# Altium schematic sheet Adafruit_Feather_adapter_Rev._1.21.SchDoc
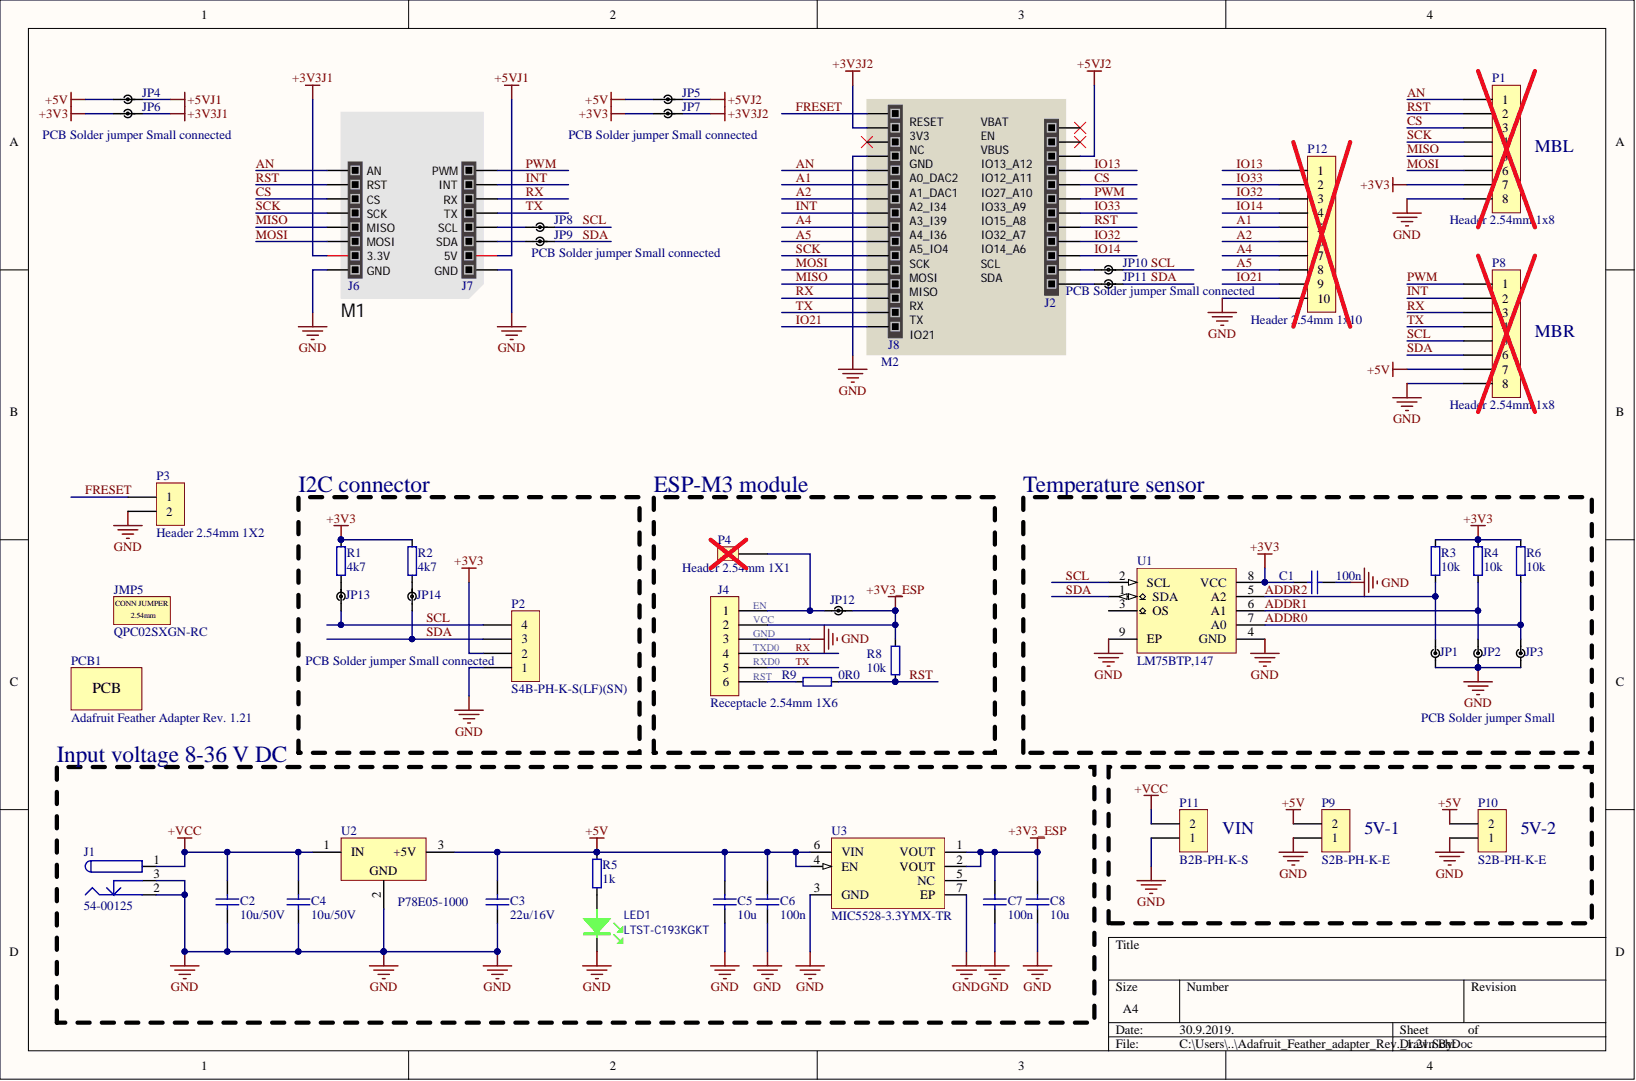
[diagram p0: Adafruit_Feather_adapter_Rev._1.21.SchDoc]

## Parts
C1  100n  [C-0603]
C2  10u/50V  [C-1210]
C3  22u/16V  [C-1210]
C4  10u/50V  [C-1210]
C5  10u  [C-1206]
C6  100n  [C-0603]
C7  100n  [C-0603]
C8  10u  [C-1206]
J1  54-00125  [Conn_54-00125]
J2  CES-112-01-T-S  [SCOKET12X01]  (value not drawn)
J4  Receptacle 2.54mm 1X6  [Receptacle 2.54mm 1X6]
J6  SSQ-108-01-T-S  [MIKROE_ROW_1x8]  (value not drawn)
J7  SSQ-108-01-T-S  [MIKROE_ROW_1x8]  (value not drawn)
J8  SLW-116-01-T-S  [SCOKET16X01]  (value not drawn)
JMP5  QPC02SXGN-RC  (mechanical)
JP1  PCB Solder jumper Small  [PCB solder jumper small]  (no BOM)
JP2  PCB Solder jumper Small  [PCB solder jumper small]  (no BOM)
JP3  PCB Solder jumper Small  [PCB solder jumper small]  (no BOM)
JP4  PCB Solder jumper Small connected  [PCB solder jumper small - connected]
JP5  PCB Solder jumper Small connected  [PCB solder jumper small - connected]
JP6  PCB Solder jumper Small connected  [PCB solder jumper small - connected]
JP7  PCB Solder jumper Small connected  [PCB solder jumper small - connected]
JP8  PCB Solder jumper Small connected  [PCB solder jumper small - connected]
JP9  PCB Solder jumper Small connected  [PCB solder jumper small - connected]
JP10  PCB Solder jumper Small connected  [PCB solder jumper small - connected]
JP11  PCB Solder jumper Small connected  [PCB solder jumper small - connected]
JP12  PCB Solder jumper Small connected  [PCB solder jumper small - connected]
JP13  PCB Solder jumper Small connected  [PCB solder jumper small - connected]
JP14  PCB Solder jumper Small connected  [PCB solder jumper small - connected]
LED1  LTST-C193KGKT  [LED-0603]
M1  MIKROBUS HOST  [MIKROBUS HOST CONN.]  (value not drawn)  (no BOM)
M2  FEATHER  [FEATHERHOST]  (value not drawn)  (no BOM)
P1  Header 2.54mm 1x8  [CONN_Header_2.54mm_1X8]
P2  S4B-PH-K-S(LF)(SN)  [Conn_S4B-PH-K-S]
P3  Header 2.54mm 1X2  [CONN_Header_2.54mm_1X2]
P4  Header 2.54mm 1X1  [Header 2.54mm 1X1]
P8  Header 2.54mm 1x8  [CONN_Header_2.54mm_1X8]
P9  S2B-PH-K-E  [Conn_S2B-PH-K-E]
P10  S2B-PH-K-E  [Conn_S2B-PH-K-E]
P11  B2B-PH-K-S  [Conn B2B-PH-K-S]
P12  Header 2.54mm 1x10  [CONN_Header_2.54mm_1X10]
PCB1  PCB  (mechanical)
R1  4k7  [R-0603]
R2  4k7  [R-0603]
R3  10k  [R-0603]
R4  10k  [R-0603]
R5  1k  [R-0603]
R6  10k  [R-0603]
R8  10k  [R-0603]
R9  0R0  [R-0603]
U1  LM75BTP,147  [DFN50P200X300X75-8T150]
U2  P78E05-1000  [SIP-3]
U3  MIC5528-3.3YMX-TR  [DFN40P120X120X40-6T30X94]

## Nets
+3V3: C1.1 JP6.1 JP7.1 P1.7 P2.2 R1.2 R2.2 R3.2 R4.2 R6.2 U1.8(VCC)
+3V3_ESP: C7.2 C8.2 J4.2 JP12.2 R8.2 U3.1(VOUT) U3.2(VOUT)
+3V3J1: J6.7 JP6.2
+3V3J2: J8.2 JP7.2
+5V: C3.2 C5.2 C6.2 JP4.1 JP5.1 P8.7 P9.2 P10.2 R5.2 U2.3 U3.4(EN) U3.6(VIN)
+5VJ1: J7.7 JP4.2
+5VJ2: J2.3 JP5.2
+VCC: C2.2 C4.2 J1.1 P11.2 U2.1
GND: C1.2 C2.1 C3.1 C4.1 C5.1 C6.1 C7.1 C8.1 J1.2 J1.3 J4.3 J6.8 J7.8 J8.4 JP1.2 JP2.2 JP3.2 LED1.2 P1.8 P2.1 P3.2 P8.8 P9.1 P10.1 P11.1 P12.10 U1.4(GND) U1.9(EP) U2.2 U3.3(GND) U3.7(EP)
A1: J8.6 P12.5
A2: J8.7 P12.6
A4: J8.9 P12.7
A5: J8.10 P12.8
ADDR0: JP3.1 R6.1 U1.7(A0)
ADDR1: JP2.1 R4.1 U1.6(A1)
ADDR2: JP1.1 R3.1 U1.5(A2)
AN: J6.1 J8.5 P1.1
CS: J2.5 J6.3 P1.3
FRESET: J8.1 P3.1
INT: J7.2 J8.8 P8.2
IO13: J2.4 P12.1
IO14: J2.10 P12.4
IO21: J8.16 P12.9
IO32: J2.9 P12.3
IO33: J2.7 P12.2
MISO: J6.5 J8.13 P1.5
MOSI: J6.6 J8.12 P1.6
PWM: J2.6 J7.1 P8.1
RST: J2.8 J6.2 P1.2 R8.1 R9.1
RX: J4.4 J7.3 J8.14 P8.3
SCK: J6.4 J8.11 P1.4
SCL: JP8.2 JP10.2 JP13.1 P2.4 P8.5 U1.2(SCL)
SDA: JP9.2 JP11.2 JP14.1 P2.3 P8.6 U1.1(SDA)
TX: J4.5 J7.4 J8.15 P8.4
NetJ2_11: J2.11 JP10.1
NetJ2_12: J2.12 JP11.1
NetJ4_1: J4.1 JP12.1 P4.1
NetJ4_6: J4.6 R9.2
NetJ7_5: J7.5 JP8.1
NetJ7_6: J7.6 JP9.1
NetJP13_2: JP13.2 R1.1
NetJP14_2: JP14.2 R2.1
NetLED1_1: LED1.1 R5.1

Not connected: J2 1 2; J8 3; U1 3(OS); U3 5(NC)

## Library data of the parts
C1 C6 C7 = C0603-100n-50V-X7R: Capacitor ceramic 100n X7R 50V 0603; BL Part Number: 2000-637; Checked_By: Puskar; Drawn_By: Dodig; Component Kind: Standard; DateModified: 14-06-2013; Library Name: BL_Passive.SchLib; Manufacturer: N/A; Manufacturer Part Number: N/A; Signal Integrity: C0603; Simulation: CAP; Supplier 1: Digi-Key; Supplier Part Number 1: 490-4779-1-ND
C2 C4 = C1210-10u-50V-X7R: Capacitor ceramic 10u ±10% X7R 50V 1210; Manufacturer: Samsung Electro-Mechanics; Manufacturer Part Number: CL32B106KBJNNWE; Drawn_By: Zvonc; Component Kind: Standard; DateModified: 13-05-2019; Supplier 1: Digi-Key; Supplier Part Number 1: 1276-3388-1-ND; Library Name: Tobias_Passive.SchLib
C3 = C1210-22u-16V-X7R: Capacitor ceramic 22u ±15% X7R 16V 1210; BL Part Number: 2001-340; Manufacturer: Murata; Manufacturer Part Number: GCM32ER71C226ME19L; Checked_By: Dodig; Drawn_By: Puskar; Note: Promijenjen DigiKey PN na dobavljivu komponentu; Component Kind: Standard; DateModified: 16-11-2013; Library Name: BL_Passive.SchLib; Simulation: CAP; Supplier 1: Digi-Key; Supplier Part Number 1: 445-3955-1-ND
C5 C8 = C1206-10u-16V-X7R: Capacitor ceramic 10u ±10 X7R 16V 1206; BL Part Number: 2000-447; Checked_By: Zvonc; Drawn_By: Dodig; Component Kind: Standard; DateModified: 04-07-2013; Library Name: BL_Passive.SchLib; Manufacturer: N/A; Manufacturer Part Number: N/A; Simulation: CAP; Supplier 1: Digi-Key; Supplier Part Number 1: 1276-1137-1-ND
J1 = Conn Barrel 54-00125: Barrel Power Connector, 1.1/3.5mm (ID/OD), 48V/3.5A; Manufacturer: Tensility International Corp; Drawn_By: Zvonc; Component Kind: Standard; DateModified: 31-05-2019; Manufacturer Part Number: 54-00125; Supplier 1: Digi-Key; Supplier Part Number 1: 839-1508-ND; Library Name: Tobias_Mechanical.SchLib
J2 = ROW_01X12: Manufacturer: Samtec; Component Kind: Standard; Description: CES-112-01-T-S; Library Name: Connectors.IntLib; Manufacturer Part Number: CES-112-01-T-S; PartNumber: CES-112-01-T-S; Supplier 1: Mouser; Supplier Link 1: https://www.mouser.at/ProductDetail/Samtec/CES-112-01-T-S?qs=sGAEpiMZZMs%252bGHln7q6pm21GiQusZaT7HElltZv7SA0%3d; Supplier Part Number 1: 200-CES11201TS
J4 = Conn Receptacle 2.54mm 1X6: 2.54mm pitch 6 pin (1x6) top entry wire to board connector THT; BL Part Number: 2003-501; Manufacturer: Samtec; Manufacturer Part Number: SSQ-106-01-T-S; Drawn_By: Zvonc; Component Kind: Standard; DateModified: 15-09-2017; Supplier 1: Digi-Key; Supplier Part Number 1: SAM1184-06-ND; Library Name: Tobias_Mechanical.SchLib
J6 J7 = MIKROE_ROW_01X08: Manufacturer: Samtec; Component Kind: Standard; Description: SSQ-108-01-T-S; Library Name: Connectors.SchLib; Manufacturer Part Number: SSQ-108-01-T-S; PartNumber: SSQ-108-01-T-S; Supplier 1: Mouser; Supplier Link 1: https://www.mouser.at/ProductDetail/Samtec/SSQ-108-01-T-S?qs=sGAEpiMZZMs%252bGHln7q6pm8Vn94ktop%2fJQMA9o%252bSmScjSBLV7TdKl6g==; Supplier Part Number 1: 200-SSQ10801TS
J8 = ROW_01X16: Manufacturer: Samtec; Component Kind: Standard; Description: SLW-116-01-T-S; Library Name: Connectors.IntLib; Manufacturer Part Number: SLW-116-01-T-S; PartNumber: SLW-116-01-T-S; Supplier 1: Mouser; Supplier Link 1: https://www.mouser.at/ProductDetail/Samtec/SLW-116-01-T-S?qs=sGAEpiMZZMs%252bGHln7q6pm1g2HIpDMMemmyoSwZxINYmZdVcAL1EU4A%3d%3d; Supplier Part Number 1: 200-SLW11601TS
JMP5 = Conn Jumper 2.54mm: CONN JUMPER SHORTING .100" GOLD; BL Part Number: 2000-174; Manufacturer: Sullins Connector Solutions; Checked_By: Zvonc; Drawn_By: Dodig; DateModified: 15-06-2016; Library Name: BL_Mechanical.SchLib; Manufacturer Part Number: QPC02SXGN-RC; Supplier 1: Digi-Key; Supplier Part Number 1: S9337-ND
JP1-JP3 = PCB Solder jumper Small: Checked_By: Dodig; Drawn_By: Puskar; BL Part Number: N/A; DateModified: 04-11-2013; Description: PCB Solder jumper Small; Library Name: BL_Mechanical.SchLib; Manufacturer: N/A; Manufacturer Part Number: N/A
JP4-JP14 = PCB Solder jumper Small connected: PCB Solder jumper Small - connected; Drawn_By: Zvonc; BL Part Number: N/A; Component Kind: Net Tie; DateModified: 26-01-2016; Library Name: BL_Mechanical.SchLib; Manufacturer: N/A; Manufacturer Part Number: N/A
LED1 = LTST-C193KGKT: Standard LEDs - SMD Green, 571nm 12mcd, 5mA; Manufacturer: LiteON; Manufacturer Part Number: LTST-C193KGKT-5A; Fixed: NO; Component Kind: Standard; Library Name: LEDs.IntLib; Mounted: YES; PartNumber: LTST-C193KGKT-5A; Supplier 1: Mouser; Supplier Link 1: http://www.mouser.at/ProductDetail/Lite-On/LTST-C193KGKT-5A/?qs=%2fha2pyFaduj%252bwTWyfQGTE6Xg7B2sI2cG%252b%252bq3NWSFTtmxnIwkCmyoYQ%3d%3d; Supplier Part Number 1: 859-LTSTC193KGKT5A
M1 = MIKROBUS HOST: mikroBUS Host Socket; Library Name: Connectors.SchLib
P1 P8 = Conn Header 2.54mm 1X8: 2.54mm pitch 8 pin (1x8) top entry wire to board connector THT; BL Part Number: 2001-479; Manufacturer: Sullins Connector Solutions; Manufacturer Part Number: PREC008SAAN-RC; Checked_By: Dodig; Drawn_By: Puskar; Component Kind: Standard; DateModified: 07-12-2013; Supplier 1: Digi-Key; Supplier Part Number 1: S1012EC-08-ND; Library Name: Tobias_Mechanical.SchLib
P2 = Conn S4B-PH-K-S(LF)(SN): 2mm pitch 4 pin side entry wire to board connector THT; Manufacturer: JST; Drawn_By: Zvonc; Component Kind: Standard; DateModified: 27-09-2018; Manufacturer Part Number: S4B-PH-K-S(LF)(SN); Supplier 1: Digi-Key; Supplier Part Number 1: 455-1721-ND; Library Name: Tobias_Mechanical.SchLib
P3 = Conn Header 2.54mm 1X2: 2.54mm pitch 2 pin (1x2) top entry wire to board connector THT; BL Part Number: 2001-495; Manufacturer: Amphenol ICC (FCI); Manufacturer Part Number: 68001-402HLF; Checked_By: Puskar; Drawn_By: Dodig; Component Kind: Standard; DateModified: 23-12-2013; Library Name: Tobias_Mechanical.SchLib
P4 = Conn Header 2.54mm 1X1: 2.54mm pitch 1 pin (1x1) top entry wire to board connector THT; BL Part Number: NA; Checked_By: Dodig; Drawn_By: Puskar; Component Kind: Standard; DateModified: 20-02-2014; Library Name: BL_Mechanical.SchLib; Manufacturer: N/A; Manufacturer Part Number: N/A
P9 P10 = Conn S2B-PH-K-E: 2.00mm pitch 2 pin side entry wire to board connector, THT; Manufacturer: JST; Drawn_By: Zvonc; Component Kind: Standard; DateModified: 26-09-2019; Manufacturer Part Number: S2B-PH-K-E; Library Name: Tobias_Mechanical.SchLib
P11 = Conn B2B-PH-K-S: 2.00mm pitch 2 pin top entry wire to board connector, THT; BL Part Number: 2001-625; Manufacturer: JST; Drawn_By: Puskar; Component Kind: Standard; DateModified: 15-05-2014; Library Name: BL_Mechanical.SchLib; Manufacturer Part Number: B2B-PH-K-S; Supplier 1: Digi-Key; Supplier Part Number 1: 455-1704-ND
P12 = Conn Header 2.54mm 1X10: 2.54mm pitch 10 pin (1x10) top entry wire to board connector THT; Manufacturer: Sullins Connector Solutions; Manufacturer Part Number: PREC010SAAN-RC; Drawn_By: Zvonc; Component Kind: Standard; DateModified: 31-05-2019; Supplier 1: Digi-Key; Supplier Part Number 1: S1012EC-10-ND; Library Name: Tobias_Mechanical.SchLib
PCB1 = PCB: Printed Circuit Board; BL Part Number: Adafruit Feather Adapter Rev. 1.21; Manufacturer: Byte Lab; Checked_By: Zvonc; Drawn_By: Dodig; Library Name: BL_Mechanical.SchLib
R1 R2 = R0603-4k7-1: Resistor 4k7 ±1% 0603; BL Part Number: 2001-089; Checked_By: Puskar; Drawn_By: Dodig; Component Kind: Standard; DateModified: 25-10-2013; Library Name: BL_Passive.SchLib; Manufacturer: N/A; Manufacturer Part Number: N/A; Supplier 1: Digi-Key; Supplier Part Number 1: 311-4.70KHRCT-ND
R3 R4 R6 R8 = R0603-10k-1: Resistor 10k ±1% 0603; BL Part Number: 2000-642; Checked_By: Puskar; Drawn_By: Dodig; Component Kind: Standard; DateModified: 17-06-2013; Library Name: BL_Passive.SchLib; Manufacturer: N/A; Manufacturer Part Number: N/A; Supplier 1: Digi-Key; Supplier Part Number 1: 311-10.0KHRCT-ND
R5 = R0603-1k-1: Resistor 1k ±1% 0603; BL Part Number: 2000-636; Checked_By: Puskar; Drawn_By: Dodig; Component Kind: Standard; DateModified: 14-06-2013; Library Name: BL_Passive.SchLib; Manufacturer: N/A; Manufacturer Part Number: N/A; Supplier 1: Digi-Key; Supplier Part Number 1: 311-1.00KHRCT-ND
R9 = R0603-0R0: Resistor 0R0 0603; BL Part Number: 2000-723; Checked_By: Puskar; Drawn_By: Dodig; Component Kind: Standard; DateModified: 07-12-2013; Library Name: BL_Passive.SchLib; Manufacturer: N/A; Manufacturer Part Number: N/A; Supplier 1: Digi-Key; Supplier Part Number 1: 311-0.0GRCT-ND
U1 = LM75BTP,147: SENSOR DIGITAL -55C-125C 8HWSON; Manufacturer: NXP USA Inc.; Drawn_By: Zvonc; Component Kind: Standard; DateModified: 11-05-2019; Manufacturer Part Number: LM75BTP,147; Supplier 1: Digi-Key; Supplier Part Number 1: 568-9815-1-ND; Library Name: Tobias_Digital.SchLib
U2 = P78E05-1000: DC-DC NON-ISOLATED, 1.0 A, 8-36 V IN; Manufacturer: CUI Inc.; Drawn_By: Zvonc; Component Kind: Standard; DateModified: 11-05-2019; Manufacturer Part Number: P78E05-1000; Library Name: Tobias_Analog.SchLib
U3 = MIC5528-3.3YMX-TR: IC REG LINEAR 3.3V 500MA 6TDFN; Manufacturer: Microchip Technology; Drawn_By: Zvonc; Component Kind: Standard; DateModified: 27-07-2019; Manufacturer Part Number: MIC5528-3.3YMX-TR; Supplier 1: Digi-Key; Supplier Part Number 1: 576-4452-1-ND; Library Name: Tobias_Analog.SchLib
M2 = FEATHER: Library Name: Connectors.IntLib
